# Altium schematic sheet Sheet1.SchDoc
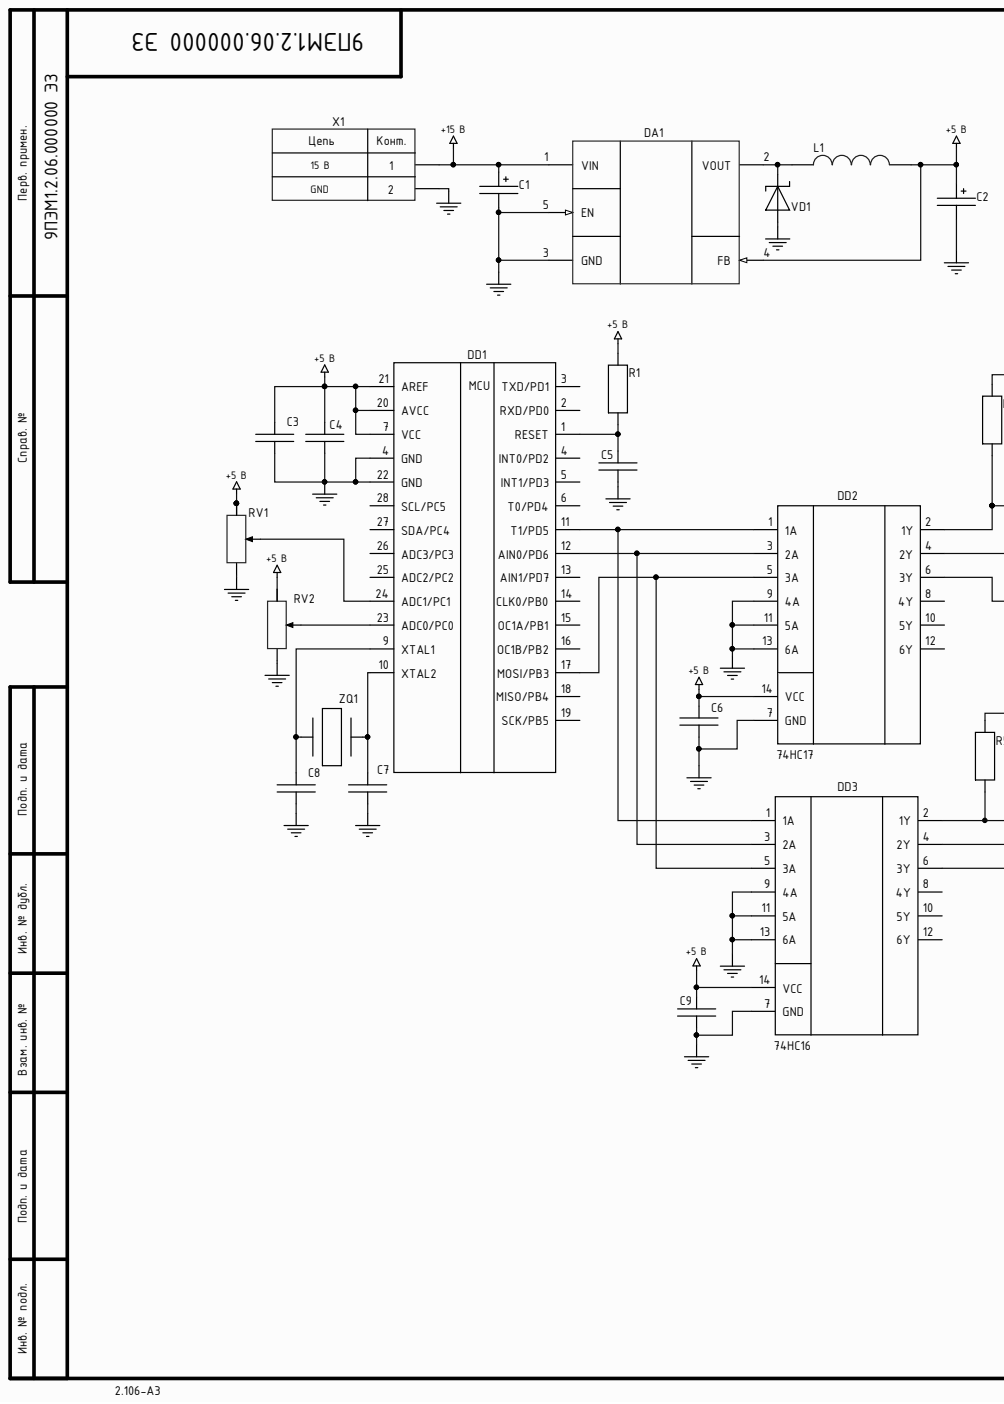
[diagram p0: Sheet1.SchDoc, left]
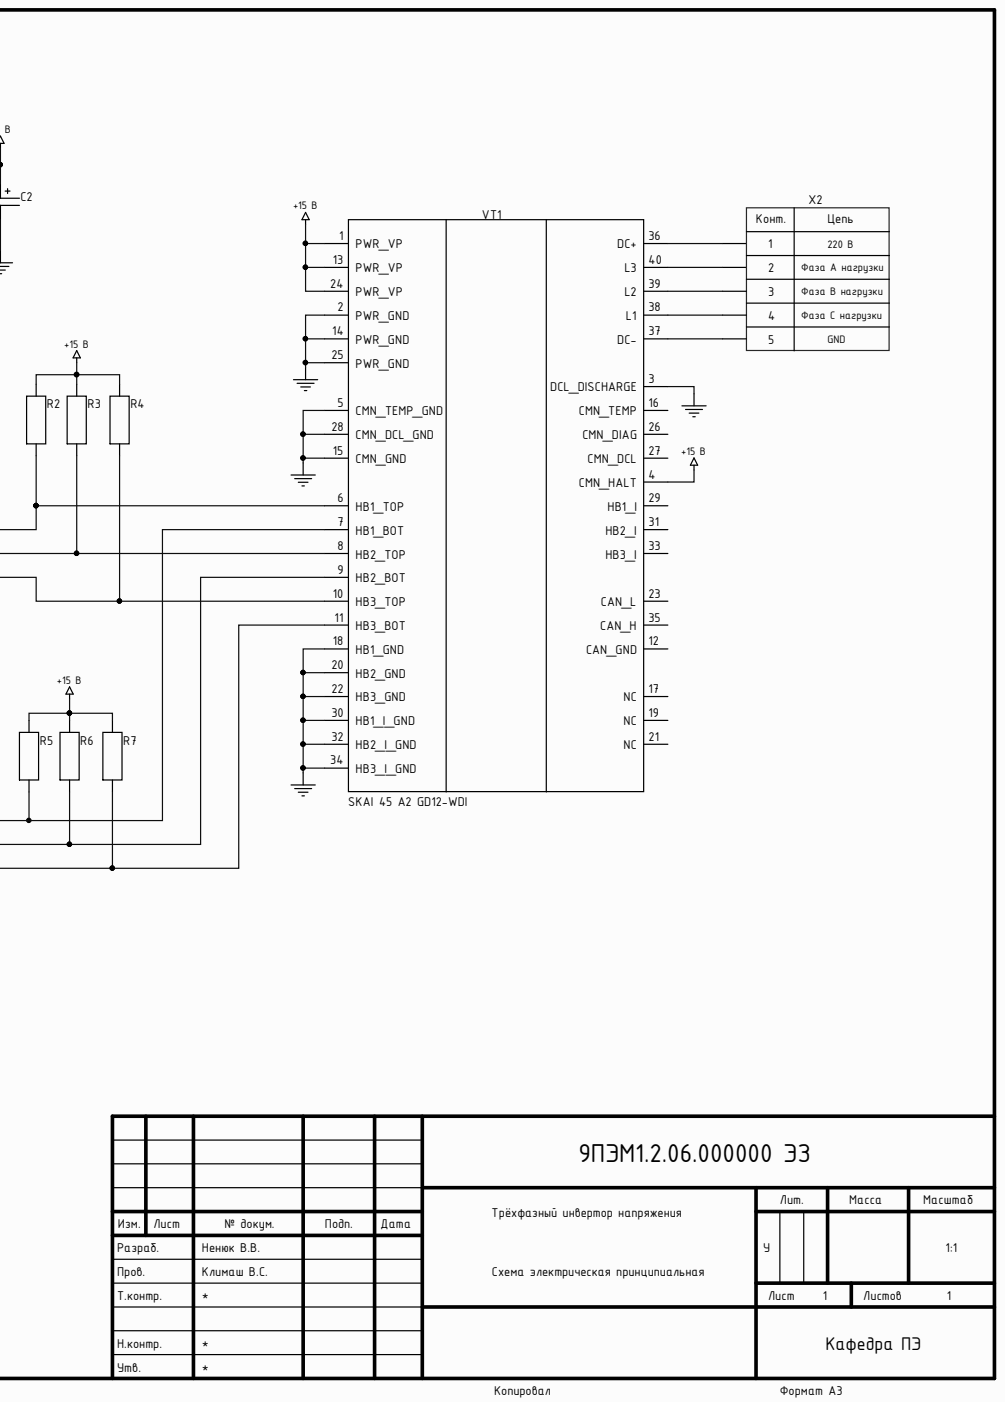
[diagram p1: Sheet1.SchDoc, right]

## Parts
C1  Tantalum capacitor, 100μF, 6.3V, ±10%, 2Ohm, case A (3216), -55...+125°C  [CAP: TANTAL-A]  (value not drawn)
C2  Tantalum capacitor, 100μF, 6.3V, ±10%, 2Ohm, case A (3216), -55...+125°C  [CAP: TANTAL-A]  (value not drawn)
C3  (no value)  [C0805]
C4  (no value)  [C0805]
C5  (no value)  [C0805]
C6  (no value)  [C0805]
C7  (no value)  [C0805]
C8  (no value)  [C0805]
C9  (no value)  [C0805]
DA1  DC/DC buck converter, input 2.7...5.5V, 1MHz, output 0.6...5V, 2A, -40...+85°C, SOT23-6  [SOT23-5]  (value not drawn)
DD1  (no value)
DD2  74HC17  [DIP-14]
DD3  74HC16  [DIP-14]
L1  Fixed inductor, 10μH, ±20%, 0603, 50mA, -55...+125°C  [IND: 0603]  (value not drawn)
R1  (no value)  [R_multilayer]
R2  (no value)  [R_multilayer]
R3  (no value)  [R_multilayer]
R4  (no value)  [R_multilayer]
R5  (no value)  [R_multilayer]
R6  (no value)  [R_multilayer]
R7  (no value)  [R_multilayer]
RV1  Resistor film, 10k, ±10%, 3296W, 0.5W, -55...+125°C  [RES: 3296W]  (value not drawn)
RV2  Resistor film, 10k, ±10%, 3296W, 0.5W, -55...+125°C  [RES: 3296W]  (value not drawn)
VD1  Schottky diode, 80V, 500mA, 1V, 15pF, SOD-123, -55...+150°C  [DIODE: SMA]  (value not drawn)
VT1  SKAI 45 A2 GD12-WDI
X1  Connector, 2pin, 9.53mm, 300VAC, 25A  [CON: 6PCV-02-006]  (value not drawn)
X2  Connector, 5pin, 1.25mm, 50VDC, 1A  [CON: SM05B-GHS]  (value not drawn)
ZQ1  (no value)

## Nets
+5 В: C2.1 C3.2 C4.2 C6.2 C9.2 DA1.4(FB) DD1.7(VCC) DD1.20(AVCC) DD1.21(AREF) DD2.14(VCC) DD3.14(VCC) L1.1 R1.2 RV1.1 RV2.1
+15 В: C1.1 DA1.1(VIN) R2.2 R3.2 R4.2 R5.2 R6.2 R7.2 VT1.1(PWR_VP) VT1.4(CMN_HALT) VT1.13(PWR_VP) VT1.24(PWR_VP) X1.1
GND: C1.2 C2.2 C3.1 C4.1 C5.1 C6.1 C7.1 C8.1 C9.1 DA1.3(GND) DA1.5(EN) DD1.4 DD1.22(GND) DD2.7(GND) DD2.9(4A) DD2.11(5A) DD2.13(6A) DD3.7(GND) DD3.9(4A) DD3.11(5A) DD3.13(6A) RV1.3 RV2.3 VD1.1 VT1.2(PWR_GND) VT1.3(DCL_DISCHARGE) VT1.5(CMN_TEMP_GND) VT1.14(PWR_GND) VT1.15(CMN_GND) VT1.18(HB1_GND) VT1.20(HB2_GND) VT1.22(HB3_GND) VT1.25(PWR_GND) VT1.28(CMN_DCL_GND) VT1.30(HB1_I_GND) VT1.32(HB2_I_GND) VT1.34(HB3_I_GND) X1.2
NetC5_2: C5.2 DD1.1(RESET) R1.1
NetC7_2: C7.2 DD1.10(XTAL2) ZQ1.2
NetC8_2: C8.2 DD1.9(XTAL1) ZQ1.1
NetDA1_2: DA1.2(VOUT) L1.2 VD1.2
NetDD1_11: DD1.11(T1/PD5) DD2.1(1A) DD3.1(1A)
NetDD1_12: DD1.12(AIN0/PD6) DD2.3(2A) DD3.3(2A)
NetDD1_17: DD1.17(MOSI/PB3) DD2.5(3A) DD3.5(3A)
NetDD1_23: DD1.23(ADC0/PC0) RV2.2
NetDD1_24: DD1.24(ADC1/PC1) RV1.2
NetDD2_2: DD2.2(1Y) R2.1 VT1.6(HB1_TOP)
NetDD2_4: DD2.4(2Y) R3.1 VT1.8(HB2_TOP)
NetDD2_6: DD2.6(3Y) R4.1 VT1.10(HB3_TOP)
NetDD3_2: DD3.2(1Y) R5.1 VT1.7(HB1_BOT)
NetDD3_4: DD3.4(2Y) R6.1 VT1.9(HB2_BOT)
NetDD3_6: DD3.6(3Y) R7.1 VT1.11(HB3_BOT)
NetVT1_36: VT1.36(DC+) X2.1
NetVT1_37: VT1.37(DC-) X2.5
NetVT1_38: VT1.38(L1) X2.4
NetVT1_39: VT1.39(L2) X2.3
NetVT1_40: VT1.40(L3) X2.2

Not connected: DD1 2(RXD/PD0) 3(TXD/PD1) 5(INT1/PD3) 6(T0/PD4) 13(AIN1/PD7) 14(CLK0/PB0) 15(OC1A/PB1) 16(OC1B/PB2) 18(MISO/PB4) 19(SCK/PB5) 25(ADC2/PC2) 26(ADC3/PC3) 27(SDA/PC4) 28(SCL/PC5); DD2 8(4Y) 10(5Y) 12(6Y); DD3 8(4Y) 10(5Y) 12(6Y); VT1 12(CAN_GND) 16(CMN_TEMP) 17(NC) 19(NC) 21(NC) 23(CAN_L) 26(CMN_DIAG) 27(CMN_DCL) 29(HB1_I) 31(HB2_I) 33(HB3_I) 35(CAN_H)

## Library data of the parts
C1 C2 = Tantal_A: Manufacturer: AVX; PartNumber: F930J107KAAAJ6; TypeDielectric: X7R; Component Kind: Standard; HelpURL: https://www.digikey.com/product-detail/en/avx-corporation/F930J107KAAAJ6/478-12404-1-ND/9645231; Impedance: 2Ohm; Library Name: Capacitor.IntLib; Package: case A (3216); Temperature: -55...+125°C; Tolerance: ±10%; TypeCapacitor: Tantalum capacitor; Value: 100μF; ValueManufacturerPartNumber: Tantalum capacitor, 100μF, 6.3V, ±10%, 2Ohm, case A (3216), -55...+125°C; Voltage: 6.3V
C3-C9 = Ceramic capacitor: Capacitor; Component Kind: Standard; Library Name: My_Library.IntLib
DA1 = AP3429AKTTR-G1: Buck converter; Manufacturer: Diodes Incorporated; Component Kind: Standard; ComponentType: DC/DC buck converter; CurrentOutput: 2A; Frequency: 1MHz; HelpURL: https://www.digikey.com/product-detail/en/diodes-incorporated/AP3429AKTTR-G1/AP3429AKTTR-G1DICT-ND/5359842; Library Name: IC. Power supply.IntLib; Package: SOT23-6; Temperature: -40...+85°C; ValueManufacturerPartNumber: DC/DC buck converter, input 2.7...5.5V, 1MHz, output 0.6...5V, 2A, -40...+85°C, SOT23-6; VoltageInput: input 2.7...5.5V; VoltageOutput: output 0.6...5V
DD1 = ATmega328P: MCU; Component Kind: Standard; Library Name: My_Library.IntLib
DD2 = 74HC14: Hex buffer; Component Kind: Standard; ComponentKind: DIP-14; Library Name: My_Library.IntLib; Model: 74HC17
DD3 = 74HC14: Hex inverter; Component Kind: Standard; ComponentKind: DIP-14; Library Name: My_Library.IntLib; Model: 74HC16
L1 = Inductor_0603: Manufacturer: Murata Electronics; PartNumber: LQM18FN100M00D; Component Kind: Standard; HelpURL: https://www.digikey.com/product-detail/en/murata-electronics-north-america/LQM18FN100M00D/490-4025-1-ND/1016285; Library Name: Inductor.IntLib; MaxCurrent: 50mA; Package: 0603; Temperature: -55...+125°C; Tolerance: ±20%; TypeInductor: Fixed inductor; Value: 10μH; ValueManufacturerPartNumber: Fixed inductor, 10μH, ±20%, 0603, 50mA, -55...+125°C
R1-R7 = Resistor: SMD Resistor; Component Kind: Standard; Library Name: My_Library.IntLib
RV1 RV2 = Trimmer: Manufacturer: Bourns; PartNumber: 3296W-1-103LF; Component Kind: Standard; HelpURL: https://www.digikey.com/product-detail/en/bourns-inc/3296W-1-103LF/3296W-103LF-ND/1088045; Library Name: Resistor.IntLib; Package: 3296W; Power: 0.5W; Temperature: -55...+125°C; Tolerance: ±10%; TypeResistor: Resistor film; Value: 10k; ValueManufacturerPartNumber: Resistor film, 10k, ±10%, 3296W, 0.5W, -55...+125°C
VD1 = Schottky_single: Manufacturer: Diodes Incorporated; PartNumber: MBR0580S1-7; Component Kind: Standard; Current: 500mA; HelpURL: https://www.digikey.com/product-detail/en/diodes-incorporated/MBR0580S1-7/MBR0580S1-7DICT-ND/5080371; Library Name: Diode.IntLib; Package: SOD-123; RecoveryTime: 15pF; Temperature: -55...+150°C; TypeDiode: Schottky diode; ValueManufacturerPartNumber: Schottky diode, 80V, 500mA, 1V, 15pF, SOD-123, -55...+150°C; Voltage: 80V; VoltageDrop: 1V
VT1 = SKAI_45: IGBT inverter; Component Kind: Standard; Library Name: My_Library.IntLib; Model: SKAI 45 A2 GD12-WDI
X1 = Connector_6PCV-02: Manufacturer: TE Connectivity; PartNumber: 6PCV-02-006; CommentText: "Разъем"; Component Kind: Standard; CurrentMax: 25A; HelpURL: https://www.digikey.com/products/en?keywords=6PCV-02-006; Library Name: Connector.IntLib; Multichannel: 2pin; Pitch: 9.53mm; TypeConnector: Connector; ValueManufacturerPartNumber: Connector, 2pin, 9.53mm, 300VAC, 25A; VoltageMax: 300VAC
X2 = Connector_SM05B: Manufacturer: JST; PartNumber: SM05B-GHS; CommentText: "Разъем"; Component Kind: Standard; CurrentMax: 1A; HelpURL: https://www.digikey.com/product-detail/en/jst-sales-america-inc/SM05B-GHS-TB-LF-SN/455-1567-1-ND/807835; Library Name: Connector.IntLib; Multichannel: 5pin; Pitch: 1.25mm; TypeConnector: Connector; ValueManufacturerPartNumber: Connector, 5pin, 1.25mm, 50VDC, 1A; VoltageMax: 50VDC
ZQ1 = Crystal: Component Kind: Standard; Description: Crystal; Library Name: My_Library.IntLib
